# Altium schematic sheet Schematic.SchDoc (continued)

## Library data of the parts
C1 C13 = C0805C152M4RECTU: Ceramic Capacitor, Multilayer, Ceramic, 16V, 20% +Tol, 20% -Tol, X7R, 15% TC, 0.0015uF, Surface Mount, 0805; Manufacturer: KEMET; Capacitor Type: Ceramic; Category: LDO; Component Kind: Standard; ComponentLink1Description: https://datasheet.ciiva.com:443/pdfs/VipMasterIC/IC/KEME/KEME-S-A0008958450/KEME-S-A0008958450-1.pdf?src-supplier=IHS+Markit; ComponentLink1URL: https://datasheet.ciiva.com:443/pdfs/VipMasterIC/IC/KEME/KEME-S-A0008958450/KEME-S-A0008958450-1.pdf?src-supplier=IHS+Markit; Dielectric Material: Ceramic; Library Name: Schlib2.SchLib; Manufacturer Part Number: C0805C152M4RECTU; Pins: 2; Pricing: 1=0.26, 10=0.167, 50=0.167, 100=0.085, 1000=0.072, 10000=0.042 (USD); Reach SVHC Compliant: Yes; RoHS: Yes; RoHS Compliant: Yes; Stock: 7551; Supplier: Mouser; Supplier Part Number: 80-C0805C152M4RECTU
C2 C3 = 16SVPG680M: Aluminum Electrolytic Capacitor, Polarized, Aluminum (solid Polymer), 16V, 20% +Tol, 20% -Tol, 680uF, Surface Mount, 3333; Manufacturer: Panasonic; Category: Polymer Capacitors; Component Kind: Standard; ComponentLink1Description: https://datasheet.octopart.com/16SVPG680M-Panasonic-datasheet-151609408.pdf?src-supplier=Master+Electronics; ComponentLink1URL: https://datasheet.octopart.com/16SVPG680M-Panasonic-datasheet-151609408.pdf?src-supplier=Master+Electronics; Library Name: Schlib2.SchLib; Manufacturer Part Number: 16SVPG680M; Pricing: 1=2.22, 10=1.95, 50=1.88, 100=1.24, 1000=1.24, 10000=1.24 (USD); RoHS: No; RoHS Compliant: Yes; Stock: 1775; Supplier: Mouser; Supplier Part Number: 667-16SVPG680M
C4 C16-C19 = 16SVPG270M: Aluminum Electrolytic Capacitor, Polarized, Aluminum (solid Polymer), 16V, 20% +Tol, 20% -Tol, 270uF, Surface Mount, 2626; Manufacturer: Panasonic; Capacitor Type: Aluminium; ESR (Equivalent Series Resistance): 8mR; Leakage Current: 864uA; Ripple Current: 290mA; Tan Delta: 0.12; Case/Package: 2626; Category: Polymer Capacitors; Component Kind: Standard; ComponentLink1Description: https://datasheet.ciiva.com:443/pdfs/VipMasterIC/IC/PANA/PANA-S-A0009094447/PANA-S-A0009094447-1.pdf?src-supplier=IHS+Markit; ComponentLink1URL: https://datasheet.ciiva.com:443/pdfs/VipMasterIC/IC/PANA/PANA-S-A0009094447/PANA-S-A0009094447-1.pdf?src-supplier=IHS+Markit; ComponentLink2Description: http://industrial.panasonic.com/www-data/pdf/AAB8000/AAB8000CE14.pdf?src-supplier=Avnet; ComponentLink2URL: http://industrial.panasonic.com/www-data/pdf/AAB8000/AAB8000CE14.pdf?src-supplier=Avnet; ComponentLink3Description: http://industrial.panasonic.com/cdbs/www-data/pdf/AAB8000/AAB8000C175.pdf?src-supplier=Farnell; ComponentLink3URL: http://industrial.panasonic.com/cdbs/www-data/pdf/AAB8000/AAB8000C175.pdf?src-supplier=Farnell; ComponentLink4Description: http://www.farnell.com/datasheets/1950075.pdf?src-supplier=Element14; ComponentLink4URL: http://www.farnell.com/datasheets/1950075.pdf?src-supplier=Element14; ComponentLink5Description: https://datasheet.octopart.com/16SVPG270M-Panasonic-datasheet-138704366.pdf?src-supplier=TME; ComponentLink5URL: https://datasheet.octopart.com/16SVPG270M-Panasonic-datasheet-138704366.pdf?src-supplier=TME; ComponentLink6Description: https://datasheet.octopart.com/16SVPG270M-Panasonic-datasheet-151609408.pdf?src-supplier=Master+Electronics; ComponentLink6URL: https://datasheet.octopart.com/16SVPG270M-Panasonic-datasheet-151609408.pdf?src-supplier=Master+Electronics; Diameter: 6.3mm; Dielectric Material: Aluminium; Length: 9.9mm; Library Name: Schlib2.SchLib; Manufacturer Part Number: 16SVPG270M; Max Operating Temperature: 105°C; Min Operating Temperature: -55°C; Mounting Technology: Surface Mount; Package Style: SMTMeter; Packaging: Tape and Reel; Pins: 2; Polarity: Polarized; Pricing: 1=1.62, 10=0.996, 50=0.996, 100=0.896, 1000=0.662, 10000=0.596 (USD); Rated DC Voltage (URdc): 16V; Reach SVHC Compliant: Yes; RoHS: Yes; RoHS Compliant: Yes; Stock: 23509; Supplier: Mouser; Supplier Part Number: 667-16SVPG270M; Terminal Pitch: 2.1mm; Termination: Flat; Tolerance  (fill in as +-): 20%; Tolerance (fill in as +-): 20%; Value: 270uF; Width: 6.6mm
C5 = EKXL401ELL101ML30S: Aluminum Electrolytic Capacitor, Polarized, Aluminum (wet), 400V, 20% +Tol, 20% -Tol, 100uF, Through Hole Mount; Manufacturer: United Chemi-Con; Component Kind: Standard; ComponentLink1Description: https://datasheet.ciiva.com:443/pdfs/VipMasterIC/IC/NIPC/NIPC-S-A0004676570/NIPC-S-A0004676570-1.pdf?src-supplier=IHS+Markit; ComponentLink1URL: https://datasheet.ciiva.com:443/pdfs/VipMasterIC/IC/NIPC/NIPC-S-A0004676570/NIPC-S-A0004676570-1.pdf?src-supplier=IHS+Markit; ComponentLink2Description: http://www.chemi-con.co.jp/cgi-bin/CAT_DB/SEARCH/cat_db_al.cgi?e=e&amp;j=p&amp;pdfname=kxl&src-supplier=Digikey; ComponentLink2URL: http://www.chemi-con.co.jp/cgi-bin/CAT_DB/SEARCH/cat_db_al.cgi?e=e&amp;j=p&amp;pdfname=kxl&src-supplier=Digikey; Library Name: Schlib2.SchLib; Manufacturer Part Number: EKXL401ELL101ML30S; Pricing: 1=2.24, 10=1.72, 50=1.58, 100=1.31, 1000=0.94, 10000=0.711 (USD); RoHS: Yes; Stock: 266; Supplier: Mouser; Supplier Part Number: 661-EKXL401ELL101ML3
C6 = C1206S223KARACTU: Ceramic Capacitor, Multilayer, Ceramic, 250V, 10% +Tol, 10% -Tol, X7R, 15% TC, 0.022uF, Surface Mount, 1206; Manufacturer: KEMET; Multilayer: Yes; Capacitor Type: Ceramic; Case/Package: 1206; Category: Ceramic Capacitors; Component Kind: Standard; ComponentLink1Description: https://datasheet.ciiva.com:443/pdfs/VipMasterIC/IC/KEME/KEME-S-A0008958426/KEME-S-A0008958426-1.pdf?src-supplier=IHS+Markit; ComponentLink1URL: https://datasheet.ciiva.com:443/pdfs/VipMasterIC/IC/KEME/KEME-S-A0008958426/KEME-S-A0008958426-1.pdf?src-supplier=IHS+Markit; ComponentLink2Description: https://datasheet.octopart.com/C1206S223KARACTU-KEMET-datasheet-82521703.pdf?src-supplier=Newark; ComponentLink2URL: https://datasheet.octopart.com/C1206S223KARACTU-KEMET-datasheet-82521703.pdf?src-supplier=Newark; ComponentLink3Description: https://api.kemet.com/component-edge/download/datasheet/C1206S223KARAC7800.pdf?src-supplier=Digikey; ComponentLink3URL: https://api.kemet.com/component-edge/download/datasheet/C1206S223KARAC7800.pdf?src-supplier=Digikey; Dielectric Material: Ceramic; Height: 0.78mm; JESD-609 Code: e3; Length: 3.2mm; Library Name: Schlib2.SchLib; Manufacturer Part Number: C1206S223KARACTU; Max Operating Temperature: 125°C; Min Operating Temperature: -55°C; Package Style: SMTMeter; Packaging: Tape and Reel; Pins: 2; Pricing: 1=0.15, 10=0.06, 50=0.06, 100=0.035, 1000=0.031, 10000=0.024 (USD); Rated DC Voltage (URdc): 250V; Reach SVHC Compliant: Yes; RoHS: Yes; RoHS Compliant: Yes; Stock: 45057; Supplier: Mouser; Supplier Part Number: 80-C1206S223KAR; Temperature Characteristics Code: X7R; Terminal Finish: Nickel; Tolerance  (fill in as +-): 10%; Tolerance (fill in as +-): 10%; Value: 22nF; Width: 1.6mm
C7 = C1206C335K4RACTU: Ceramic Capacitor, Multilayer, Ceramic, 16V, 10% +Tol, 10% -Tol, X7R, 15% TC, 3.3uF, Surface Mount, 1206; Manufacturer: KEMET; Multilayer: Yes; Capacitor Type: Ceramic; Case/Package: 1206; Category: Ceramic Capacitors; Component Kind: Standard; ComponentLink1Description: https://datasheet.ciiva.com:443/pdfs/VipMasterIC/IC/KEME/KEME-S-A0008991654/KEME-S-A0008991654-1.pdf?src-supplier=IHS+Markit; ComponentLink1URL: https://datasheet.ciiva.com:443/pdfs/VipMasterIC/IC/KEME/KEME-S-A0008991654/KEME-S-A0008991654-1.pdf?src-supplier=IHS+Markit; ComponentLink2Description: https://datasheet.ciiva.com/14607/70095383-14607478.pdf?src-supplier=Allied+Electronics; ComponentLink2URL: https://datasheet.ciiva.com/14607/70095383-14607478.pdf?src-supplier=Allied+Electronics; Dielectric Material: Ceramic; Height: 1mm; JESD-609 Code: e3; Length: 3.2mm; Library Name: Schlib2.SchLib; Manufacturer Part Number: C1206C335K4RACTU; Max Operating Temperature: 125°C; Min Operating Temperature: -55°C; Mounting Technology: Surface Mount; Package Shape: Rectangular; Package Style: SMTMeter; Packaging: Tape and Reel; Pins: 2; Pricing: 1=0.31, 10=0.171, 50=0.171, 100=0.11, 1000=0.097, 10000=0.097 (USD); Rated DC Voltage (URdc): 16V; Reach SVHC Compliant: Yes; RoHS: Yes; RoHS Compliant: Yes; Stock: 9267; Supplier: Mouser; Supplier Part Number: 80-C1206C335K4R; Temperature Characteristics Code: X7R; Terminal Finish: Nickel; Termination: Wraparound; Tolerance  (fill in as +-): 10%; Tolerance (fill in as +-): 10%; Value: 3.3uF; Width: 1.6mm
C8 = A768EB107M1ELAE036: Aluminum Electrolytic Capacitor, Polarized, 25V, 20% +Tol, 20% -Tol, 100uF, Surface Mount, 2626; Manufacturer: KEMET; Category: Polymer Capacitors; Component Kind: Standard; Library Name: Schlib2.SchLib; Manufacturer Part Number: A768EB107M1ELAE036; Pricing: 1=0.46, 10=0.292, 50=0.292, 100=0.189, 1000=0.138, 10000=0.138 (USD); RoHS: Yes; Stock: 17392; Supplier: Mouser; Supplier Part Number: 80-A768EB107M1ELAE36
C9 = UUD1V270MCL1GS: Aluminum Electrolytic Capacitor, Polarized, Aluminum (wet), 35V, 20% +Tol, 20% -Tol, 27uF, Surface Mount, 2626; Manufacturer: Nichicon; Capacitor Type: Aluminium; Leakage Current: 9.45uA; Ripple Current: 115mA; Tan Delta: 0.12; Case/Package: 2626; Category: Aluminum Electrolytic Capacitors; Component Kind: Standard; ComponentLink1Description: https://datasheet.ciiva.com:443/pdfs/VipMasterIC/IC/NICH/NICH-S-A0009240538/NICH-S-A0009240538-1.pdf?src-supplier=IHS+Markit; ComponentLink1URL: https://datasheet.ciiva.com:443/pdfs/VipMasterIC/IC/NICH/NICH-S-A0009240538/NICH-S-A0009240538-1.pdf?src-supplier=IHS+Markit; ComponentLink2Description: https://datasheet.ciiva.com/97/e-ud-97009.pdf?src-supplier=Digikey; ComponentLink2URL: https://datasheet.ciiva.com/97/e-ud-97009.pdf?src-supplier=Digikey; ComponentLink3Description: https://datasheet.ciiva.com/97/e-ud-97010.pdf?src-supplier=Digikey; ComponentLink3URL: https://datasheet.ciiva.com/97/e-ud-97010.pdf?src-supplier=Digikey; ComponentLink4Description: https://datasheet.ciiva.com/97/e-ud-97011.pdf?src-supplier=Digikey; ComponentLink4URL: https://datasheet.ciiva.com/97/e-ud-97011.pdf?src-supplier=Digikey; ComponentLink5Description: https://datasheet.ciiva.com/11686/e-ud-11686278.pdf?src-supplier=Avnet; ComponentLink5URL: https://datasheet.ciiva.com/11686/e-ud-11686278.pdf?src-supplier=Avnet; ComponentLink6Description: https://datasheet.ciiva.com/16513/e-ud-16513052.pdf?src-supplier=Future+Electronics; ComponentLink6URL: https://datasheet.ciiva.com/16513/e-ud-16513052.pdf?src-supplier=Future+Electronics; Dielectric Material: Aluminium; Height: 5.8mm; JESD-609 Code: e6; Length: 7mm; Library Name: Schlib2.SchLib; Manufacturer Part Number: UUD1V270MCL1GS; Max Operating Temperature: 105°C; Min Operating Temperature: -55°C; Mounting Technology: Surface Mount; Package Shape: Cylindrical; Package Style: SMTMeter; Packaging: Tape and Reel; Pins: 2; Polarity: Polarized; Pricing: 1=0.47, 10=0.234, 50=0.234, 100=0.162, 1000=0.128, 10000=0.105 (USD); Rated DC Voltage (URdc): 35V; Reach SVHC Compliant: No; RoHS: Yes; RoHS Compliant: Yes; Stock: 2774; Supplier: Mouser; Supplier Part Number: 647-UUD1V270MCL; Terminal Finish: Tin; Termination: Flat; Tolerance  (fill in as +-): 20%; Tolerance (fill in as +-): 20%; Value: 27uF; Width: 6.6mm
C10 = C1210C226M4PAC: Ceramic Capacitor, Multilayer, Ceramic, 16V, 20% +Tol, 20% -Tol, X5R, 15% TC, 22uF, Surface Mount, 1210; Manufacturer: KEMET; Multilayer: Yes; Capacitor Type: Ceramic; Case/Package: 1210; Category: Ceramic Capacitors; Component Kind: Standard; ComponentLink1Description: https://datasheet.ciiva.com:443/pdfs/VipMasterIC/IC/KEME/KEME-S-A0008991665/KEME-S-A0008991665-1.pdf?src-supplier=IHS+Markit; ComponentLink1URL: https://datasheet.ciiva.com:443/pdfs/VipMasterIC/IC/KEME/KEME-S-A0008991665/KEME-S-A0008991665-1.pdf?src-supplier=IHS+Markit; ComponentLink2Description: http://www.mouser.com/ds/2/212/KEM_C1006_X5R_SMD-1103249.pdf?src-supplier=Mouser; ComponentLink2URL: http://www.mouser.com/ds/2/212/KEM_C1006_X5R_SMD-1103249.pdf?src-supplier=Mouser; ComponentLink3Description: http://www.kemet.com/kemet/web/homepage/kechome.nsf/weben/ADB5E2666EC6BA90CA2570A50016092E/$file/KEM_C1005_Y5V_SMD.pdf?src-supplier=Avnet; ComponentLink3URL: http://www.kemet.com/kemet/web/homepage/kechome.nsf/weben/ADB5E2666EC6BA90CA2570A50016092E/$file/KEM_C1005_Y5V_SMD.pdf?src-supplier=Avnet; Dielectric Material: Ceramic; Height: 2.5mm; JESD-609 Code: e3; Length: 3.2mm; Library Name: Schlib2.SchLib; Manufacturer Part Number: C1210C226M4PAC; Max Operating Temperature: 85°C; Min Operating Temperature: -55°C; Mounting Technology: Surface Mount; Package Shape: Rectangular; Package Style: SMTMeter; Packaging: Bulk; Pins: 2; Pricing: 1=0.61, 10=0.554, 50=0.554, 100=0.38, 1000=0.266, 10000=0.221 (USD); Rated DC Voltage (URdc): 16V; Reach SVHC Compliant: Yes; RoHS: Yes; RoHS Compliant: Yes; Stock: 534; Supplier: Mouser; Supplier Part Number: 80-C1210C226M4PAC; Temperature Characteristics Code: X5R; Terminal Finish: Nickel; Termination: Wraparound; Tolerance  (fill in as +-): 20%; Tolerance (fill in as +-): 20%; Value: 22uF; Width: 2.5mm
C11 = C0805C222K4RECAUTO: Ceramic Capacitor, Multilayer, Ceramic, 16V, 10% +Tol, 10% -Tol, X7R, 15% TC, 0.0022uF, Surface Mount, 0805; Manufacturer: KEMET; Capacitor Type: Ceramic; Category: Ceramic Capacitors; Component Kind: Standard; ComponentLink1Description: https://datasheet.ciiva.com:443/pdfs/VipMasterIC/IC/KEME/KEME-S-A0008958450/KEME-S-A0008958450-1.pdf?src-supplier=IHS+Markit; ComponentLink1URL: https://datasheet.ciiva.com:443/pdfs/VipMasterIC/IC/KEME/KEME-S-A0008958450/KEME-S-A0008958450-1.pdf?src-supplier=IHS+Markit; ComponentLink2Description: https://api.kemet.com/component-edge/download/datasheet/C0805C222K4RECAUTO.pdf?src-supplier=Digikey; ComponentLink2URL: https://api.kemet.com/component-edge/download/datasheet/C0805C222K4RECAUTO.pdf?src-supplier=Digikey; Dielectric Material: Ceramic; Library Name: Schlib2.SchLib; Manufacturer Part Number: C0805C222K4RECAUTO; Pins: 2; Pricing: 1=0.24, 10=0.163, 50=0.163, 100=0.093, 1000=0.057, 10000=0.044 (USD); Reach SVHC Compliant: Yes; RoHS: Yes; RoHS Compliant: Yes; Stock: 5770; Supplier: Mouser; Supplier Part Number: 80-C0805C222K4RECAUT
C12 = C0603C104K8RAC: Ceramic Capacitor, Multilayer, Ceramic, 10V, 10% +Tol, 10% -Tol, X7R, 15% TC, 0.1uF, Surface Mount, 0603; Manufacturer: KEMET; Multilayer: Yes; Value: 100nF; Capacitor Type: Ceramic; Case/Package: 0603; Category: Ceramic Capacitors; Component Kind: Standard; ComponentLink1Description: https://datasheet.ciiva.com:443/pdfs/VipMasterIC/IC/KEME/KEME-S-A0008991654/KEME-S-A0008991654-1.pdf?src-supplier=IHS+Markit; ComponentLink1URL: https://datasheet.ciiva.com:443/pdfs/VipMasterIC/IC/KEME/KEME-S-A0008991654/KEME-S-A0008991654-1.pdf?src-supplier=IHS+Markit; ComponentLink2Description: http://www.mouser.com/ds/2/212/KEM_C1002_X7R_SMD-1102033.pdf?src-supplier=Mouser; ComponentLink2URL: http://www.mouser.com/ds/2/212/KEM_C1002_X7R_SMD-1102033.pdf?src-supplier=Mouser; Dielectric Material: Ceramic; Height: 0.8mm; JESD-609 Code: e3; Length: 1.6mm; Library Name: Schlib2.SchLib; Manufacturer Part Number: C0603C104K8RAC; Max Operating Temperature: 125°C; Min Operating Temperature: -55°C; Mounting Technology: Surface Mount; Package Shape: Rectangular; Package Style: SMTMeter; Packaging: Tape and Reel; Pins: 2; Pricing: 1=0.1, 10=0.061, 50=0.061, 100=0.031, 1000=0.022, 10000=0.015 (USD); Rated DC Voltage (URdc): 10V; Reach SVHC Compliant: Yes; RoHS: Yes; RoHS Compliant: Yes; Stock: 209299; Supplier: Mouser; Supplier Part Number: 80-C0603C104K8RAC; Temperature Characteristics Code: X7R; Terminal Finish: Nickel; Termination: Wraparound; Tolerance  (fill in as +-): 10%; Tolerance (fill in as +-): 10%; Width: 0.8mm
C14 = C0805C180JBGACTU: Ceramic Capacitor, Multilayer, Ceramic, 630V, 5% +Tol, 5% -Tol, C0G, 30ppm/Cel TC, 0.000018uF, Surface Mount, 0805; Manufacturer: KEMET; Multilayer: Yes; Capacitor Type: Ceramic; Case/Package: 0805; Category: Ceramic Capacitors; Component Kind: Standard; ComponentLink1Description: https://datasheet.ciiva.com:443/pdfs/VipMasterIC/IC/KEME/KEME-S-A0008491074/KEME-S-A0008491074-1.pdf?src-supplier=IHS+Markit; ComponentLink1URL: https://datasheet.ciiva.com:443/pdfs/VipMasterIC/IC/KEME/KEME-S-A0008491074/KEME-S-A0008491074-1.pdf?src-supplier=IHS+Markit; ComponentLink2Description: https://datasheet.ciiva.com/13376/kem-c1009-c0g-hv-smd-13376539.pdf?src-supplier=Mouser; ComponentLink2URL: https://datasheet.ciiva.com/13376/kem-c1009-c0g-hv-smd-13376539.pdf?src-supplier=Mouser; Dielectric Material: Ceramic; Height: 1.25mm; JESD-609 Code: e3; Length: 2mm; Library Name: Schlib2.SchLib; Manufacturer Part Number: C0805C180JBGACTU; Max Operating Temperature: 125°C; Min Operating Temperature: -55°C; Mounting Technology: Surface Mount; Package Shape: Rectangular; Package Style: SMTMeter; Packaging: Tape and Reel; Pins: 2; Pricing: 1=0.42, 10=0.225, 50=0.225, 100=0.145, 1000=0.124, 10000=0.099 (USD); Rated DC Voltage (URdc): 630V; Reach SVHC Compliant: Yes; RoHS: Yes; RoHS Compliant: Yes; Stock: 2194; Supplier: Mouser; Supplier Part Number: 80-C0805C180JBG; Temperature Characteristics Code: C0G; Terminal Finish: Nickel; Termination: Wraparound; Tolerance  (fill in as +-): 5%; Tolerance (fill in as +-): 5%; Value: 18pF; Width: 1.25mm
C15 = C0805C182M5RACTU: Ceramic Capacitor, Multilayer, Ceramic, 50V, 20% +Tol, 20% -Tol, X7R, 15% TC, 0.0018uF, Surface Mount, 0805; Manufacturer: KEMET; Multilayer: Yes; Capacitor Type: Ceramic; Case/Package: 0805; Category: Ceramic Capacitors; Component Kind: Standard; ComponentLink1Description: https://datasheet.ciiva.com:443/pdfs/VipMasterIC/IC/KEME/KEME-S-A0008991654/KEME-S-A0008991654-1.pdf?src-supplier=IHS+Markit; ComponentLink1URL: https://datasheet.ciiva.com:443/pdfs/VipMasterIC/IC/KEME/KEME-S-A0008991654/KEME-S-A0008991654-1.pdf?src-supplier=IHS+Markit; ComponentLink2Description: http://www.mouser.com/ds/2/212/KEM_C1002_X7R_SMD-1102033.pdf?src-supplier=Mouser; ComponentLink2URL: http://www.mouser.com/ds/2/212/KEM_C1002_X7R_SMD-1102033.pdf?src-supplier=Mouser; ComponentLink3Description: https://search.kemet.com/component-edge/download/datasheet/C0805C182M5RACTU.pdf?src-supplier=Digikey; ComponentLink3URL: https://search.kemet.com/component-edge/download/datasheet/C0805C182M5RACTU.pdf?src-supplier=Digikey; Dielectric Material: Ceramic; Height: 0.78mm; JESD-609 Code: e3; Length: 2mm; Library Name: Schlib2.SchLib; Manufacturer Part Number: C0805C182M5RACTU; Max Operating Temperature: 125°C; Min Operating Temperature: -55°C; Mounting Technology: Surface Mount; Package Shape: Rectangular; Package Style: SMTMeter; Packaging: Tape and Reel; Pins: 2; Pricing: 1=0.16, 10=0.113, 50=0.113, 100=0.094, 1000=0.062, 10000=0.044 (USD); Rated DC Voltage (URdc): 50V; Reach SVHC Compliant: Yes; RoHS: Yes; RoHS Compliant: Yes; Stock: 3640; Supplier: Mouser; Supplier Part Number: 80-C0805C182M5R; Temperature Characteristics Code: X7R; Terminal Finish: Nickel; Termination: Wraparound; Tolerance  (fill in as +-): 20%; Tolerance (fill in as +-): 20%; Value: 1.8nF; Width: 1.25mm
C20 = C0805C101J5GAC3123: Multilayer Ceramic Capacitors MLCC - SMD/SMT 50volts 100pF C0G 5%; Manufacturer: KEMET; Category: Ceramic Capacitors; Component Kind: Standard; ComponentLink1Description: https://datasheet.ciiva.com/5439/kem-c1003-c0g-smd-356956-5439045.pdf?src-supplier=Mouser; ComponentLink1URL: https://datasheet.ciiva.com/5439/kem-c1003-c0g-smd-356956-5439045.pdf?src-supplier=Mouser; Library Name: Schlib2.SchLib; Manufacturer Part Number: C0805C101J5GAC3123; Pricing: 1=0.13, 10=0.089, 50=0.089, 100=0.042, 1000=0.025, 10000=0.017 (USD); RoHS: Yes; Stock: 6802; Supplier: Mouser; Supplier Part Number: 80-C0805C101J5G3123
CONN1-CONN3 = CONNECTOR: Strip Terminal Block, 24A, 2.5mm2, 1 Row(s), 1 Deck(s); Manufacturer: Weidmuller; Additional Feature: STANDARD: UL 94V-0; Fastening Method: SOLDER; Number of Decks: 1; Number of Ways: 2; Safety Approval: UL,CSA; Voltage Rating: 600V; Wire/Cable Gauge: 14AWG; Category: Terminal Blocks; Component Kind: Standard; ComponentLink1Description: https://datasheet.ciiva.com:443/pdfs/VipMasterIC/IC/WEID/WEIDS07857/WEIDS07857-1.pdf?src-supplier=IHS+Markit; ComponentLink1URL: https://datasheet.ciiva.com:443/pdfs/VipMasterIC/IC/WEID/WEIDS07857/WEIDS07857-1.pdf?src-supplier=IHS+Markit; ComponentLink2Description: https://datasheet.ciiva.com/823/1760510000-823577.pdf?src-supplier=Digikey; ComponentLink2URL: https://datasheet.ciiva.com/823/1760510000-823577.pdf?src-supplier=Digikey; ComponentLink3Description: https://datasheet.ciiva.com/7143/1712606-7143944.pdf?src-supplier=Newark; ComponentLink3URL: https://datasheet.ciiva.com/7143/1712606-7143944.pdf?src-supplier=Newark; ComponentLink4Description: https://datasheet.ciiva.com/16071/1760510000-pm-5-08-02-90-3-5sn-bk-bx-en-pdf-154-16071541.pdf?src-supplier=Onlinecomponents.com; ComponentLink4URL: https://datasheet.ciiva.com/16071/1760510000-pm-5-08-02-90-3-5sn-bk-bx-en-pdf-154-16071541.pdf?src-supplier=Onlinecomponents.com; Current Rating: 24A; Library Name: Schlib2.SchLib; Manufacturer Part Number: 1760510000; Mounting Technology: Board; Number of Rows: 1; Pricing: 1=0.89, 10=0.554, 25=0.474, 50=0.4262, 100=0.4173, 250=0.35512, 500=0.33736, 1000=0.30185, 5000=0.23615 (USD); Reach SVHC Compliant: Yes; RoHS: Yes; RoHS Compliant: Yes; Stock: 49588; Supplier: Digikey; Supplier Part Number: 281-1882-ND; Terminal and Terminal Block Type: STRIP TERMINAL BLOCK; Wire Cross Section: 2.5mm2
D1 D6 = 150060VS75000: Single Color LED, Bright Green, Water Clear, 1.1mm; Manufacturer: Wurth Electronics; Channels: 1; Forward Current: 30mA; Luminous Intensity-Nom: 40mcd; Overall Height: 0.7mm; Shape: Rectangular; Viewing Angle: 140°; Category: LEDs; Color: Green; Component Kind: Standard; ComponentLink1Description: https://datasheet.ciiva.com:443/pdfs/VipMasterIC/IC/MIDC/MIDC-S-A0007768636/MIDC-S-A0007768636-1.pdf?src-supplier=IHS+Markit; ComponentLink1URL: https://datasheet.ciiva.com:443/pdfs/VipMasterIC/IC/MIDC/MIDC-S-A0007768636/MIDC-S-A0007768636-1.pdf?src-supplier=IHS+Markit; ComponentLink2Description: https://datasheet.ciiva.com/29562/150060vs75000-29562919.pdf?src-supplier=Digikey; ComponentLink2URL: https://datasheet.ciiva.com/29562/150060vs75000-29562919.pdf?src-supplier=Digikey; Lens Style: WATER CLEAR; Library Name: Schlib2.SchLib; Manufacturer Part Number: 150060VS75000; Max Operating Temperature: 85°C; Min Operating Temperature: -40°C; Mounting Technology: Surface Mount; Optoelectronic Device Type: SINGLE COLOR LED; Packaging: Tape and Reel; Pins: 2; Pricing: 1=0.15, 50=0.142, 100=0.122, 500=0.105, 1000=0.10125 (USD); Reach SVHC Compliant: Yes; RoHS: Yes; RoHS Compliant: Yes; Size: 1.1mm; Stock: 90757; Supplier: Digikey; Supplier Part Number: 732-4980-1-ND; Terminal Pitch: 1.6mm
D2 D5 = V10P22CHM3/H: Schottky Diodes & Rectifiers 10A 200V SMPC TRENCH SKY REC; Manufacturer: Vishay Intertechnology; Component Kind: Standard; Library Name: Schlib2.SchLib; Manufacturer Part Number: V10P22CHM3/H; Pricing: 1=1, 10=0.819, 50=0.819, 100=0.637, 1000=0.44, 10000=0.413 (USD); RoHS: No; Stock: 1323; Supplier: Mouser; Supplier Part Number: 78-V10P22CHM3/H
D3 = US1M-M3/5AT: Rectifier Diode, 1 Phase, 1 Element, 1A, 1000V V(RRM), Silicon, DO-214AC; Manufacturer: Vishay Semiconductors; Additional Feature: FREE WHEELING DIODE; Number of Elements: 1; Package Body Material: Plastic; Rep Pk Reverse Voltage-Max: 1kV; Reverse Recovery Time-Max: 0.075us; Category: Rectifier Diodes; Component Kind: Standard; ComponentLink1Description: https://datasheet.ciiva.com:443/pdfs/VipMasterIC/IC/VISH/VISH-S-A0003405547/VISH-S-A0003405547-1.pdf?src-supplier=IHS+Markit; ComponentLink1URL: https://datasheet.ciiva.com:443/pdfs/VipMasterIC/IC/VISH/VISH-S-A0003405547/VISH-S-A0003405547-1.pdf?src-supplier=IHS+Markit; ComponentLink2Description: https://datasheet.ciiva.com/29886/us1-29886014.pdf?src-supplier=Avnet; ComponentLink2URL: https://datasheet.ciiva.com/29886/us1-29886014.pdf?src-supplier=Avnet; Diode Element Material: SILICON; Diode Type: RECTIFIER; JEDEC-95 Code: DO-214AC; JESD-30 Code: R-PDSO-C2; JESD-609 Code: e3; Library Name: Schlib2.SchLib; Manufacturer Part Number: US1M-M3/5AT; Max Operating Temperature: 150°C; Max Output current: 1A; Min Operating Temperature: -55°C; Package Shape: Rectangular; Package Style: SMALL OUTLINEMeter; Pins: 2; Pricing: 1=0.31, 10=0.209, 50=0.209, 100=0.109, 1000=0.089, 10000=0.065 (USD); Reach SVHC Compliant: No; RoHS: Yes; RoHS Compliant: Yes; Stock: 35964; Supplier: Mouser; Supplier Part Number: 78-US1M-M35AT; Surface Mount: Yes; Terminal Finish: Tin; Terminal Form: C BEND; Terminal Position: DUAL
D4 = VS-MBRS1100-M3/5BT: Rectifier Diode, Schottky, 1 Phase, 1 Element, 1A, 100V V(RRM), Silicon; Manufacturer: Vishay Semiconductors; Additional Feature: FREE WHEELING DIODE; Number of Elements: 1; Package Body Material: Plastic; Category: Rectifier Diodes; Component Kind: Standard; ComponentLink1Description: https://datasheet.ciiva.com:443/pdfs/VipMasterIC/IC/VISH/VISH-S-A0009498371/VISH-S-A0009498371-1.pdf?src-supplier=IHS+Markit; ComponentLink1URL: https://datasheet.ciiva.com:443/pdfs/VipMasterIC/IC/VISH/VISH-S-A0009498371/VISH-S-A0009498371-1.pdf?src-supplier=IHS+Markit; ComponentLink2Description: http://www.farnell.com/datasheets/2119788.pdf?src-supplier=Farnell; ComponentLink2URL: http://www.farnell.com/datasheets/2119788.pdf?src-supplier=Farnell; ComponentLink3Description: http://www.mouser.com/ds/2/427/vsmbrs190m3-552910.pdf?src-supplier=Mouser; ComponentLink3URL: http://www.mouser.com/ds/2/427/vsmbrs190m3-552910.pdf?src-supplier=Mouser; ComponentLink4Description: http://www.vishay.com/docs/95744/vsmbrs190m3.pdf?src-supplier=Digikey; ComponentLink4URL: http://www.vishay.com/docs/95744/vsmbrs190m3.pdf?src-supplier=Digikey; ComponentLink5Description: https://www.vishay.com/docs/95744/vsmbrs190m3.pdf?src-supplier=Digikey; ComponentLink5URL: https://www.vishay.com/docs/95744/vsmbrs190m3.pdf?src-supplier=Digikey; ComponentLink6Description: https://datasheet.octopart.com/VS-MBRS1100-M3-5BT-Vishay-datasheet-66581896.pdf?src-supplier=Newark; ComponentLink6URL: https://datasheet.octopart.com/VS-MBRS1100-M3-5BT-Vishay-datasheet-66581896.pdf?src-supplier=Newark; ComponentLink7Description: http://www.farnell.com/datasheets/2119788.pdf?src-supplier=Element14; ComponentLink7URL: http://www.farnell.com/datasheets/2119788.pdf?src-supplier=Element14; ComponentLink8Description: https://datasheet.octopart.com/VS-MBRS1100-M3-5BT-Vishay-datasheet-48227625.pdf?src-supplier=Future+Electronics; ComponentLink8URL: https://datasheet.octopart.com/VS-MBRS1100-M3-5BT-Vishay-datasheet-48227625.pdf?src-supplier=Future+Electronics; Diode Element Material: SILICON; Diode Type: RECTIFIER; JESD-30 Code: R-PDSO-C2; JESD-609 Code: e3; Library Name: Schlib2.SchLib; Manufacturer Part Number: VS-MBRS1100-M3/5BT; Max Operating Temperature: 175°C; Min Operating Temperature: -55°C; Moisture Sensitivity Level: 1; Package Shape: Rectangular; Package Style: SMALL OUTLINEMeter; Peak Reflow Temperature (Cel): 260°C; Pins: 2; Pricing: 1=0.35, 10=0.237, 50=0.237, 100=0.122, 1000=0.113, 10000=0.075 (USD); Reach SVHC Compliant: No; Rep Pk Reverse Voltage-Max: 100V; RoHS: Yes; RoHS Compliant: Yes; Stock: 190719; Supplier: Mouser; Supplier Part Number: 78-VS-MBRS1100-M35BT; Surface Mount: Yes; Technology: SCHOTTKY; Terminal Finish: Tin; Terminal Form: C BEND; Terminal Position: DUAL
L1 = BDQQ00201212R11MPA: Power Inductor, 0.11UH, Shielded, 10A Rohs Compliant: Yes; Manufacturer: Pulse; Component Kind: Standard; Library Name: Schlib2.SchLib; Manufacturer Part Number: BDQQ00201212R11MPA; Pricing: 1=0.21, 10=0.177, 50=0.177, 100=0.126, 1000=0.086, 10000=0.073 (USD); RoHS: No; Stock: 4600; Supplier: Mouser; Supplier Part Number: 673-BDQQ201212R11MPA
L2 = AIAC-2712C-17N5J-T: General Purpose Inductor, 0.0175uH, 5%, 1 Element, Air-Core, SMD, 2310; Manufacturer: Abracon; Channels: 1; Core Material: Air; DC Resistance (DCR): 4.5mR; Inductor Application: HIGH CURRENT INDUCTOR; Max Current Rating: 4A; Quality Factor-Min (at L-nom): 100; Self Resonant Frequency: 2.2GHz; Shape/Size Description: RECTANGULAR PACKAGE; Shielding: Unshielded; Test Frequency: 150MHz; Category: Fixed Inductors; Component Kind: Standard; ComponentLink1Description: https://datasheet.ciiva.com:443/pdfs/VipMasterIC/IC/ABRC/ABRC-S-A0008586417/ABRC-S-A0008586417-1.pdf?src-supplier=IHS+Markit; ComponentLink1URL: https://datasheet.ciiva.com:443/pdfs/VipMasterIC/IC/ABRC/ABRC-S-A0008586417/ABRC-S-A0008586417-1.pdf?src-supplier=IHS+Markit; ComponentLink2Description: https://datasheet.ciiva.com/13313/aiac-2712c-218660-13313076.pdf?src-supplier=Mouser; ComponentLink2URL: https://datasheet.ciiva.com/13313/aiac-2712c-218660-13313076.pdf?src-supplier=Mouser; ComponentLink3Description: https://datasheet.ciiva.com/6691/aiac-2712c-6691014.pdf?src-supplier=Digikey; ComponentLink3URL: https://datasheet.ciiva.com/6691/aiac-2712c-6691014.pdf?src-supplier=Digikey; ComponentLink4Description: https://datasheet.ciiva.com/6691/aiac-2712c-6691016.pdf?src-supplier=Digikey; ComponentLink4URL: https://datasheet.ciiva.com/6691/aiac-2712c-6691016.pdf?src-supplier=Digikey; Inductance-Nom (L): 0.0175uH; Inductor Type: GENERAL PURPOSE INDUCTOR; Library Name: Schlib2.SchLib; Manufacturer Part Number: AIAC-2712C-17N5J-T; Max Operating Temperature: 125°C; Min Operating Temperature: -40°C; Pins: 2; Pricing: 1=0.26, 10=0.25, 50=0.25, 100=0.248, 1000=0.247, 10000=0.222 (USD); Reach SVHC Compliant: Yes; RoHS: Yes; RoHS Compliant: Yes; Stock: 3506; Supplier: Mouser; Supplier Part Number: 815-AIAC2712C17N5JT; Surface Mount: Yes; Terminal Placement: Dual Ended; Termination: Flat; Tolerance: 5%
Q1 = IPD60R180CM8XTMA1: Mosfet, N-ch, 600V, 18A, TO-252;; Manufacturer: Infineon; Category: MOSFETs; Component Kind: Standard; Library Name: Schlib2.SchLib; Manufacturer Part Number: IPD60R180CM8XTMA1; Pricing: 1=2.48, 10=1.6, 100=1.0956, 500=0.87982, 1000=0.81047 (USD); RoHS: No; Stock: 620; Supplier: Digikey; Supplier Part Number: 448-IPD60R180CM8XTMA1CT-ND
R1 = RT0805DRD07120RL: Fixed Resistor, Thin Film, 0.125W, 120ohm, 150V, 0.5% +/-Tol, 25ppm/Cel, Surface Mount, 0805; Manufacturer: Yageo; Additional Feature: HIGH PRECISION; Package Height: 0.5mm; Package Length: 2mm; Package Width: 1.25mm; Power: 125mW; Rated Temperature: 70°C; Temperature Coefficient: 25ppm/°C; Case/Package: 0805; Category: Chip SMD Resistors; Component Kind: Standard; ComponentLink1Description: https://datasheet.ciiva.com:443/pdfs/VipMasterIC/IC/YAGO/YAGO-S-A0008510989/YAGO-S-A0008510989-1.pdf?src-supplier=IHS+Markit; ComponentLink1URL: https://datasheet.ciiva.com:443/pdfs/VipMasterIC/IC/YAGO/YAGO-S-A0008510989/YAGO-S-A0008510989-1.pdf?src-supplier=IHS+Markit; ComponentLink2Description: http://www.yageo.com/documents/recent/PYu-RT_1-to-0.01_RoHS_L_9.pdf?src-supplier=Digikey; ComponentLink2URL: http://www.yageo.com/documents/recent/PYu-RT_1-to-0.01_RoHS_L_9.pdf?src-supplier=Digikey; Construction: Chip; Library Name: Schlib2.SchLib; Manufacturer Part Number: RT0805DRD07120RL; Max Operating Temperature: 155°C; Min Operating Temperature: -55°C; Mounting Technology: Surface Mount; Package Style: SMTMeter; Packaging: Tape and Reel; Pins: 2; Pricing: 1=0.1, 10=0.076, 50=0.0606, 100=0.0545, 500=0.0418, 1000=0.03701 (USD); Reach SVHC Compliant: Yes; Resistor Type: FIXED RESISTOR; RoHS: Yes; RoHS Compliant: Yes; Stock: 4920; Supplier: Digikey; Supplier Part Number: 13-RT0805DRD07120RLCT-ND; Technology: THIN FILM; Termination: Wraparound; Tolerance: 0.5%; Value: 120R; Working Voltage: 150V
R2 R19 = RNCL1206FTR500: Thin Film Resistors - SMD 0.5Ohms 1206 1W 1% HiPow Anti-Surge AEC-Q200; Manufacturer: Stackpole Electronics; Component Kind: Standard; Library Name: Schlib2.SchLib; Manufacturer Part Number: RNCL1206FTR500; Pricing: 1=0.36, 10=0.255, 50=0.197, 100=0.175, 1000=0.111, 10000=0.093 (USD); RoHS: No; Stock: 7659; Supplier: Mouser; Supplier Part Number: 708-RNCL1206FTR500
R3 R14 = RT0603BRE0750RL: Fixed Resistor, Thin Film, 0.1W, 50ohm, 75V, 0.1% +/-Tol, 50ppm/Cel, Surface Mount, 0603; Manufacturer: Yageo; Additional Feature: LASER TRIMMABLE; Power: 100mW; Rated Temperature: 70°C; Temperature Coefficient (ppm/Cel): 50; Case/Package: 0603; Category: Chip SMD Resistors; Component Kind: Standard; ComponentLink1Description: https://datasheet.ciiva.com:443/pdfs/VipMasterIC/IC/YAGO/YAGO-S-A0003507815/YAGO-S-A0003507815-1.pdf?src-supplier=IHS+Markit; ComponentLink1URL: https://datasheet.ciiva.com:443/pdfs/VipMasterIC/IC/YAGO/YAGO-S-A0003507815/YAGO-S-A0003507815-1.pdf?src-supplier=IHS+Markit; ComponentLink2Description: http://www.yageo.com/documents/recent/PYu-RT_1-to-0.01_RoHS_L_9.pdf?src-supplier=Digikey; ComponentLink2URL: http://www.yageo.com/documents/recent/PYu-RT_1-to-0.01_RoHS_L_9.pdf?src-supplier=Digikey; ComponentLink3Description: https://datasheet.octopart.com/RT0603BRE0750RL-Yageo-datasheet-166250672.pdf?src-supplier=Future+Electronics; ComponentLink3URL: https://datasheet.octopart.com/RT0603BRE0750RL-Yageo-datasheet-166250672.pdf?src-supplier=Future+Electronics; Library Name: Schlib2.SchLib; Manufacturer Part Number: RT0603BRE0750RL; Max Operating Temperature: 155°C; Min Operating Temperature: -55°C; Mounting Technology: Surface Mount; Package Shape: Rectangular; Packaging: Tape and Reel; Pins: 2; Pricing: 1=0.18, 10=0.131, 50=0.103, 100=0.092, 1000=0.065, 10000=0.044 (USD); Reach SVHC Compliant: Yes; Resistor Type: FIXED RESISTOR; RoHS: Yes; RoHS Compliant: Yes; Stock: 12310; Supplier: Mouser; Supplier Part Number: 603-RT0603BRE0750RL; Technology: THIN FILM; Termination: Wraparound; Tolerance: 0.1%; Value: 50R; Working Voltage: 75V
R4 R10 = RT1206BRD0750KL: Fixed Resistor, Thin Film, 0.25W, 50000ohm, 200V, 0.1% +/-Tol, 25ppm/Cel, Surface Mount, 1206; Manufacturer: Yageo; Additional Feature: LASER TRIMMABLE; Power: 250mW; Rated Temperature: 70°C; Temperature Coefficient (ppm/Cel): 25; Value: 50kR; Case/Package: 1206; Category: Chip SMD Resistors; Component Kind: Standard; ComponentLink1Description: https://datasheet.ciiva.com:443/pdfs/VipMasterIC/IC/YAGO/YAGO-S-A0003507815/YAGO-S-A0003507815-1.pdf?src-supplier=IHS+Markit; ComponentLink1URL: https://datasheet.ciiva.com:443/pdfs/VipMasterIC/IC/YAGO/YAGO-S-A0003507815/YAGO-S-A0003507815-1.pdf?src-supplier=IHS+Markit; ComponentLink2Description: https://datasheet.octopart.com/RT1206BRD0750KL-Yageo-datasheet-166250672.pdf?src-supplier=Future+Electronics; ComponentLink2URL: https://datasheet.octopart.com/RT1206BRD0750KL-Yageo-datasheet-166250672.pdf?src-supplier=Future+Electronics; ComponentLink3Description: http://www.yageo.com/documents/recent/PYu-RT_1-to-0.01_RoHS_L_8.pdf?src-supplier=Digikey; ComponentLink3URL: http://www.yageo.com/documents/recent/PYu-RT_1-to-0.01_RoHS_L_8.pdf?src-supplier=Digikey; Library Name: Schlib2.SchLib; Manufacturer Part Number: RT1206BRD0750KL; Max Operating Temperature: 155°C; Min Operating Temperature: -55°C; Mounting Technology: Surface Mount; Package Shape: Rectangular; Packaging: Tape and Reel; Pins: 2; Pricing: 1=0.34, 10=0.244, 50=0.189, 100=0.166, 1000=0.112, 10000=0.089 (USD); Reach SVHC Compliant: Yes; Resistor Type: FIXED RESISTOR; RoHS: Yes; RoHS Compliant: Yes; Stock: 3546; Supplier: Mouser; Supplier Part Number: 603-RT1206BRD0750KL; Technology: THIN FILM; Termination: Wraparound; Tolerance: 0.1%; Working Voltage: 200V
R5 = RT0805BRD076K73L: Fixed Resistor, Thin Film, 0.125W, 6730ohm, 150V, 0.1% +/-Tol, 25ppm/Cel, Surface Mount, 0805; Manufacturer: Yageo; Additional Feature: LASER TRIMMABLE; Package Height: 0.5mm; Package Length: 2mm; Package Width: 1.25mm; Power: 125mW; Rated Temperature: 70°C; Temperature Coefficient (ppm/Cel): 25; Value: 6.73kR; Case/Package: 0805; Category: Chip SMD Resistors; Component Kind: Standard; ComponentLink1Description: https://datasheet.ciiva.com:443/pdfs/VipMasterIC/IC/YAGO/YAGO-S-A0008510989/YAGO-S-A0008510989-1.pdf?src-supplier=IHS+Markit; ComponentLink1URL: https://datasheet.ciiva.com:443/pdfs/VipMasterIC/IC/YAGO/YAGO-S-A0008510989/YAGO-S-A0008510989-1.pdf?src-supplier=IHS+Markit; ComponentLink2Description: http://www.yageo.com/documents/recent/PYu-RT_1-to-0.01_RoHS_L_8.pdf?src-supplier=Digikey; ComponentLink2URL: http://www.yageo.com/documents/recent/PYu-RT_1-to-0.01_RoHS_L_8.pdf?src-supplier=Digikey; Construction: Chip; JESD-609 Code: e3; Library Name: Schlib2.SchLib; Manufacturer Part Number: RT0805BRD076K73L; Max Operating Temperature: 155°C; Min Operating Temperature: -55°C; Mounting Technology: Surface Mount; Package Shape: Rectangular; Packaging: Tape and Reel; Pins: 2; Pricing: 1=0.17, 10=0.123, 50=0.097, 100=0.087, 1000=0.06, 10000=0.038 (USD); Reach SVHC Compliant: Yes; Resistor Type: FIXED RESISTOR; RoHS: Yes; RoHS Compliant: Yes; Stock: 9017; Supplier: Mouser; Supplier Part Number: 603-RT0805BRD076K73L; Technology: THIN FILM; Terminal Finish: Nickel; Termination: Wraparound; Tolerance: 0.1%; Working Voltage: 150V
R6 = ERA-6AHD21R0V: Fixed Resistor, Thin Film, 0.125W, 21ohm, 100V, 0.5% +/-Tol, 50ppm/Cel, Surface Mount, 0805; Manufacturer: Panasonic; Package Height: 0.5mm; Package Length: 2mm; Package Width: 1.25mm; Power: 125mW; Rated Temperature: 85°C; Reference Standard: AEC-Q200; Temperature Coefficient (ppm/Cel): 50; Case/Package: 0805; Category: Chip SMD Resistors; Component Kind: Standard; ComponentLink1Description: https://datasheet.ciiva.com:443/pdfs/VipMasterIC/IC/PANA/PANA-S-A0009459258/PANA-S-A0009459258-1.pdf?src-supplier=IHS+Markit; ComponentLink1URL: https://datasheet.ciiva.com:443/pdfs/VipMasterIC/IC/PANA/PANA-S-A0009459258/PANA-S-A0009459258-1.pdf?src-supplier=IHS+Markit; ComponentLink2Description: https://datasheet.ciiva.com/24030/aoa0000ce26-197354-24030725.pdf?src-supplier=Mouser; ComponentLink2URL: https://datasheet.ciiva.com/24030/aoa0000ce26-197354-24030725.pdf?src-supplier=Mouser; ComponentLink3Description: https://datasheet.ciiva.com/9191/aoa0000ce26-9191944.pdf?src-supplier=Avnet; ComponentLink3URL: https://datasheet.ciiva.com/9191/aoa0000ce26-9191944.pdf?src-supplier=Avnet; Construction: Rectangular; JESD-609 Code: e3; Library Name: Schlib2.SchLib; Manufacturer Part Number: ERA-6AHD21R0V; Max Operating Temperature: 155°C; Min Operating Temperature: -55°C; Mounting Technology: Surface Mount; Packaging: Tape and Reel; Pins: 2; Pricing: 1=0.19, 10=0.138, 50=0.138, 100=0.126, 1000=0.126, 10000=0.051 (USD); Reach SVHC Compliant: Yes; Resistor Type: FIXED RESISTOR; RoHS: Yes; RoHS Compliant: Yes; Stock: 19880; Supplier: Mouser; Supplier Part Number: 667-ERA-6AHD21R0V; Technology: THIN FILM; Terminal Finish: Nickel; Termination: Wraparound; Tolerance: 0.5%; Value: 21R; Working Voltage: 100V
R7 = AA0805FR-0739RL: Fixed Resistor, Metal Glaze/thick Film, 0.125W, 39ohm, 150V, 1% +/-Tol, 150ppm/Cel, Surface Mount, 0805; Manufacturer: Yageo; Additional Feature: LASER TRIMMABLE; Package Height: 0.5mm; Package Length: 2mm; Package Width: 1.25mm; Power: 125mW; Rated Temperature: 70°C; Reference Standard: AEC-Q200; Temperature Coefficient (ppm/Cel): 150; Case/Package: 0805; Category: Chip SMD Resistors; Component Kind: Standard; ComponentLink1Description: https://datasheet.ciiva.com:443/pdfs/VipMasterIC/IC/YAGO/YAGO-S-A0003674169/YAGO-S-A0003674169-1.pdf?src-supplier=IHS+Markit; ComponentLink1URL: https://datasheet.ciiva.com:443/pdfs/VipMasterIC/IC/YAGO/YAGO-S-A0003674169/YAGO-S-A0003674169-1.pdf?src-supplier=IHS+Markit; ComponentLink2Description: http://www.yageo.com/NewPortal/yageodocoutput?fileName=/pdf/R-Chip/PYu-AA_51_RoHS_L_3.pdf&src-supplier=Digikey; ComponentLink2URL: http://www.yageo.com/NewPortal/yageodocoutput?fileName=/pdf/R-Chip/PYu-AA_51_RoHS_L_3.pdf&src-supplier=Digikey; Construction: Chip; JESD-609 Code: e3; Library Name: Schlib2.SchLib; Manufacturer Part Number: AA0805FR-0739RL; Max Operating Temperature: 155°C; Min Operating Temperature: -55°C; Mounting Technology: Surface Mount; Package Shape: Rectangular; Packaging: Tape and Reel; Pins: 2; Pricing: 1=0.1, 10=0.027, 50=0.027, 100=0.024, 1000=0.015, 10000=0.007 (USD); Reach SVHC Compliant: Yes; Resistor Type: FIXED RESISTOR; RoHS: Yes; RoHS Compliant: Yes; Stock: 4035; Supplier: Mouser; Supplier Part Number: 603-AA0805FR-0739RL; Technology: METAL GLAZE/THICK FILM; Terminal Finish: Nickel; Termination: Wraparound; Tolerance: 1%; Value: 39R; Working Voltage: 150V
R8 = RT0805FRE07715RL: Fixed Resistor, Thin Film, 0.125W, 715ohm, 150V, 1% +/-Tol, 50ppm/Cel, Surface Mount, 0805; Manufacturer: Yageo; Additional Feature: HIGH PRECISION; Package Height: 0.5mm; Package Length: 2mm; Package Width: 1.25mm; Power: 125mW; Rated Temperature: 70°C; Temperature Coefficient (ppm/Cel): 50; Case/Package: 0805; Category: Chip SMD Resistors; Component Kind: Standard; ComponentLink1Description: https://datasheet.ciiva.com:443/pdfs/VipMasterIC/IC/YAGO/YAGO-S-A0008510989/YAGO-S-A0008510989-1.pdf?src-supplier=IHS+Markit; ComponentLink1URL: https://datasheet.ciiva.com:443/pdfs/VipMasterIC/IC/YAGO/YAGO-S-A0008510989/YAGO-S-A0008510989-1.pdf?src-supplier=IHS+Markit; ComponentLink2Description: https://datasheet.ciiva.com/2561/pyu-rt-1-to-0-05-rohs-l-5-2561373.pdf?src-supplier=Digikey; ComponentLink2URL: https://datasheet.ciiva.com/2561/pyu-rt-1-to-0-05-rohs-l-5-2561373.pdf?src-supplier=Digikey; Construction: Chip; Library Name: Schlib2.SchLib; Manufacturer Part Number: RT0805FRE07715RL; Max Operating Temperature: 155°C; Min Operating Temperature: -55°C; Mounting Technology: Surface Mount; Packaging: Tape and Reel; Pins: 2; Pricing: 1=0.1, 10=0.026, 50=0.026, 100=0.021, 1000=0.019, 10000=0.013 (USD); Reach SVHC Compliant: Yes; Resistor Type: FIXED RESISTOR; RoHS: Yes; RoHS Compliant: Yes; Stock: 18735; Supplier: Mouser; Supplier Part Number: 603-RT0805FRE07715RL; Technology: THIN FILM; Termination: Wraparound; Tolerance: 1%; Value: 715R; Working Voltage: 150V
R9 R13 R18 = RT0805BRD071KL: Fixed Resistor, Thin Film, 0.125W, 1000ohm, 150V, 0.1% +/-Tol, 25ppm/Cel, Surface Mount, 0805; Manufacturer: Yageo; Additional Feature: HIGH PRECISION; Package Height: 0.5mm; Package Length: 2mm; Package Width: 1.25mm; Power: 125mW; Rated Temperature: 70°C; Temperature Coefficient: 25ppm/°C; Value: 1kR; Case/Package: 0805; Category: Chip SMD Resistors; Component Kind: Standard; ComponentLink1Description: https://datasheet.ciiva.com:443/pdfs/VipMasterIC/IC/YAGO/YAGO-S-A0008510989/YAGO-S-A0008510989-1.pdf?src-supplier=IHS+Markit; ComponentLink1URL: https://datasheet.ciiva.com:443/pdfs/VipMasterIC/IC/YAGO/YAGO-S-A0008510989/YAGO-S-A0008510989-1.pdf?src-supplier=IHS+Markit; ComponentLink2Description: https://datasheet.ciiva.com/46635/pyu-rt-1-to-0-05-rohs-l-5-46635037.pdf?src-supplier=Digikey; ComponentLink2URL: https://datasheet.ciiva.com/46635/pyu-rt-1-to-0-05-rohs-l-5-46635037.pdf?src-supplier=Digikey; ComponentLink3Description: https://datasheet.ciiva.com/12836/pyu-rt-1-to-0-05-rohs-l-4-12836655.pdf?src-supplier=Mouser; ComponentLink3URL: https://datasheet.ciiva.com/12836/pyu-rt-1-to-0-05-rohs-l-4-12836655.pdf?src-supplier=Mouser; ComponentLink4Description: https://datasheet.ciiva.com/8896/pyu-rt-1-to-0-05-rohs-l-4-8896157.pdf?src-supplier=Avnet; ComponentLink4URL: https://datasheet.ciiva.com/8896/pyu-rt-1-to-0-05-rohs-l-4-8896157.pdf?src-supplier=Avnet; ComponentLink5Description: https://datasheet.ciiva.com/2584/pyu-rt-1-to-0-05-rohs-l-4-2584828.pdf?src-supplier=Digikey; ComponentLink5URL: https://datasheet.ciiva.com/2584/pyu-rt-1-to-0-05-rohs-l-4-2584828.pdf?src-supplier=Digikey; Construction: Chip; Library Name: Schlib2.SchLib; Manufacturer Part Number: RT0805BRD071KL; Max Operating Temperature: 155°C; Min Operating Temperature: -55°C; Mounting Technology: Surface Mount; Package Style: SMTMeter; Packaging: Tape and Reel; Pins: 2; Pricing: 1=0.16, 10=0.12, 50=0.0946, 100=0.0846, 500=0.06418, 1000=0.05663 (USD); Reach SVHC Compliant: Yes; Resistor Type: FIXED RESISTOR; RoHS: Yes; RoHS Compliant: Yes; Stock: 107171; Supplier: Digikey; Supplier Part Number: YAG1820CT-ND; Technology: THIN FILM; Termination: Wraparound; Tolerance: 0.1%; Working Voltage: 150V
R11 R12 = RC0805DR-072K2L: Fixed Resistor, Metal Glaze/thick Film, 0.125W, 2200ohm, 150V, 0.5% +/-Tol, 100ppm/Cel, Surface Mount, 0805; Manufacturer: Yageo; Additional Feature: LASER TRIMMABLE; Package Height: 0.5mm; Package Length: 2mm; Package Width: 1.25mm; Power: 125mW; Rated Temperature: 70°C; Temperature Coefficient: 100ppm/°C; Value: 2.2kR; Case/Package: 0805; Category: Chip SMD Resistors; Component Kind: Standard; ComponentLink1Description: https://datasheet.ciiva.com:443/pdfs/VipMasterIC/IC/YAGO/YAGO-S-A0008145938/YAGO-S-A0008145938-1.pdf?src-supplier=IHS+Markit; ComponentLink1URL: https://datasheet.ciiva.com:443/pdfs/VipMasterIC/IC/YAGO/YAGO-S-A0008145938/YAGO-S-A0008145938-1.pdf?src-supplier=IHS+Markit; ComponentLink2Description: http://www.yageo.com.tw/exep/pages/download/literatures/PYu-R_INT-thick_7.pdf?src-supplier=Digikey; ComponentLink2URL: http://www.yageo.com.tw/exep/pages/download/literatures/PYu-R_INT-thick_7.pdf?src-supplier=Digikey; Construction: Chip; JESD-609 Code: e3; Library Name: Schlib2.SchLib; Manufacturer Part Number: RC0805DR-072K2L; Max Operating Temperature: 155°C; Min Operating Temperature: -55°C; Mounting Technology: Surface Mount; Package Shape: Rectangular; Package Style: SMTMeter; Packaging: Tape and Reel; Pins: 2; Pricing: 1=0.13, 10=0.063, 50=0.0414, 100=0.0352, 500=0.02476, 1000=0.02156 (USD); Reach SVHC Compliant: Yes; Resistor Type: FIXED RESISTOR; RoHS: Yes; RoHS Compliant: Yes; Stock: 19275; Supplier: Digikey; Supplier Part Number: 13-RC0805DR-072K2LCT-ND; Technology: METAL GLAZE/THICK FILM; Terminal Finish: Nickel; Termination: Wraparound; Tolerance: 0.5%; Working Voltage: 150V
R15 = RT1206FRE07210RL: Fixed Resistor, Thin Film, 0.25W, 210ohm, 200V, 1% +/-Tol, 50ppm/Cel, Surface Mount, 1206; Manufacturer: Yageo; Additional Feature: HIGH PRECISION; Package Height: 0.55mm; Package Length: 3.1mm; Package Width: 1.6mm; Power: 250mW; Rated Temperature: 70°C; Temperature Coefficient (ppm/Cel): 50; Case/Package: 1206; Category: Chip SMD Resistors; Component Kind: Standard; ComponentLink1Description: https://datasheet.ciiva.com:443/pdfs/VipMasterIC/IC/YAGO/YAGO-S-A0008510989/YAGO-S-A0008510989-1.pdf?src-supplier=IHS+Markit; ComponentLink1URL: https://datasheet.ciiva.com:443/pdfs/VipMasterIC/IC/YAGO/YAGO-S-A0008510989/YAGO-S-A0008510989-1.pdf?src-supplier=IHS+Markit; ComponentLink2Description: https://datasheet.ciiva.com/12812/pyu-rt-1-to-0-05-rohs-l-4-12812710.pdf?src-supplier=Mouser; ComponentLink2URL: https://datasheet.ciiva.com/12812/pyu-rt-1-to-0-05-rohs-l-4-12812710.pdf?src-supplier=Mouser; Construction: Chip; Library Name: Schlib2.SchLib; Manufacturer Part Number: RT1206FRE07210RL; Max Operating Temperature: 155°C; Min Operating Temperature: -55°C; Mounting Technology: Surface Mount; Packaging: Tape and Reel; Pins: 2; Pricing: 1=0.1, 10=0.046, 50=0.046, 100=0.034, 1000=0.023, 10000=0.02 (USD); Reach SVHC Compliant: Yes; Resistor Type: FIXED RESISTOR; RoHS: Yes; RoHS Compliant: Yes; Stock: 12403; Supplier: Mouser; Supplier Part Number: 603-RT1206FRE07210RL; Technology: THIN FILM; Termination: Wraparound; Tolerance: 1%; Value: 210R; Working Voltage: 200V
R16 = RT0805FRE0710KL: Fixed Resistor, Thin Film, 0.125W, 10000ohm, 150V, 1% +/-Tol, 50ppm/Cel, Surface Mount, 0805; Manufacturer: Yageo; Additional Feature: HIGH PRECISION; Package Height: 0.5mm; Package Length: 2mm; Package Width: 1.25mm; Power: 125mW; Rated Temperature: 70°C; Temperature Coefficient (ppm/Cel): 50; Value: 10kR; Case/Package: 0805; Category: Chip SMD Resistors; Component Kind: Standard; ComponentLink1Description: https://datasheet.ciiva.com:443/pdfs/VipMasterIC/IC/YAGO/YAGO-S-A0008510989/YAGO-S-A0008510989-1.pdf?src-supplier=IHS+Markit; ComponentLink1URL: https://datasheet.ciiva.com:443/pdfs/VipMasterIC/IC/YAGO/YAGO-S-A0008510989/YAGO-S-A0008510989-1.pdf?src-supplier=IHS+Markit; ComponentLink2Description: https://datasheet.ciiva.com/29711/pyu-rt-1-to-0-05-rohs-l-4-29711644.pdf?src-supplier=Digikey; ComponentLink2URL: https://datasheet.ciiva.com/29711/pyu-rt-1-to-0-05-rohs-l-4-29711644.pdf?src-supplier=Digikey; ComponentLink3Description: https://datasheet.ciiva.com/29711/pyu-rt-1-to-0-05-rohs-l-4-29711645.pdf?src-supplier=Digikey; ComponentLink3URL: https://datasheet.ciiva.com/29711/pyu-rt-1-to-0-05-rohs-l-4-29711645.pdf?src-supplier=Digikey; ComponentLink4Description: https://datasheet.ciiva.com/25647/pyu-rt-1-to-0-05-rohs-l-4-25647309.pdf?src-supplier=Digikey; ComponentLink4URL: https://datasheet.ciiva.com/25647/pyu-rt-1-to-0-05-rohs-l-4-25647309.pdf?src-supplier=Digikey; ComponentLink5Description: https://datasheet.ciiva.com/12834/pyu-rt-1-to-0-05-rohs-l-4-12834887.pdf?src-supplier=Mouser; ComponentLink5URL: https://datasheet.ciiva.com/12834/pyu-rt-1-to-0-05-rohs-l-4-12834887.pdf?src-supplier=Mouser; ComponentLink6Description: https://datasheet.ciiva.com/7942/1392914-7942071.pdf?src-supplier=Element14; ComponentLink6URL: https://datasheet.ciiva.com/7942/1392914-7942071.pdf?src-supplier=Element14; ComponentLink7Description: https://datasheet.ciiva.com/7942/1392914-7942072.pdf?src-supplier=Element14; ComponentLink7URL: https://datasheet.ciiva.com/7942/1392914-7942072.pdf?src-supplier=Element14; Construction: Chip; Library Name: Schlib2.SchLib; Manufacturer Part Number: RT0805FRE0710KL; Max Operating Temperature: 155°C; Min Operating Temperature: -55°C; Mounting Technology: Surface Mount; Packaging: Tape and Reel; Pins: 2; Pricing: 1=0.1, 10=0.024, 50=0.024, 100=0.02, 1000=0.019, 10000=0.008 (USD); Reach SVHC Compliant: Yes; Resistor Type: FIXED RESISTOR; RoHS: Yes; RoHS Compliant: Yes; Stock: 2710; Supplier: Mouser; Supplier Part Number: 603-RT0805FRE0710KL; Technology: THIN FILM; Termination: Wraparound; Tolerance: 1%; Working Voltage: 150V
R17 = RT0805BRD0713K7L: Fixed Resistor, Thin Film, 0.125W, 13700ohm, 150V, 0.1% +/-Tol, 25ppm/Cel, Surface Mount, 0805; Manufacturer: Yageo; Additional Feature: HIGH PRECISION; Package Height: 0.5mm; Package Length: 2mm; Package Width: 1.25mm; Power: 125mW; Rated Temperature: 70°C; Temperature Coefficient (ppm/Cel): 25; Value: 13.7kR; Case/Package: 0805; Category: Chip SMD Resistors; Component Kind: Standard; ComponentLink1Description: https://datasheet.ciiva.com:443/pdfs/VipMasterIC/IC/YAGO/YAGO-S-A0008510989/YAGO-S-A0008510989-1.pdf?src-supplier=IHS+Markit; ComponentLink1URL: https://datasheet.ciiva.com:443/pdfs/VipMasterIC/IC/YAGO/YAGO-S-A0008510989/YAGO-S-A0008510989-1.pdf?src-supplier=IHS+Markit; ComponentLink2Description: https://datasheet.ciiva.com/8890/pyu-rt-1-to-0-05-rohs-l-4-8890950.pdf?src-supplier=Avnet; ComponentLink2URL: https://datasheet.ciiva.com/8890/pyu-rt-1-to-0-05-rohs-l-4-8890950.pdf?src-supplier=Avnet; Construction: Chip; Library Name: Schlib2.SchLib; Manufacturer Part Number: RT0805BRD0713K7L; Max Operating Temperature: 155°C; Min Operating Temperature: -55°C; Mounting Technology: Surface Mount; Packaging: Tape and Reel; Pins: 2; Pricing: 1=0.16, 10=0.12, 50=0.095, 100=0.085, 1000=0.057, 10000=0.038 (USD); Reach SVHC Compliant: Yes; Resistor Type: FIXED RESISTOR; RoHS: Yes; RoHS Compliant: Yes; Stock: 7040; Supplier: Mouser; Supplier Part Number: 603-RT0805BRD0713K7L; Technology: THIN FILM; Termination: Wraparound; Tolerance: 0.1%; Working Voltage: 150V
R20 = RT0805BRD07294RL: Fixed Resistor, Thin Film, 0.125W, 294ohm, 150V, 0.1% +/-Tol, 25ppm/Cel, Surface Mount, 0805; Manufacturer: Yageo; Additional Feature: HIGH PRECISION; Package Height: 0.5mm; Package Length: 2mm; Package Width: 1.25mm; Power: 125mW; Rated Temperature: 70°C; Temperature Coefficient (ppm/Cel): 25; Case/Package: 0805; Category: Chip SMD Resistors; Component Kind: Standard; ComponentLink1Description: https://datasheet.ciiva.com:443/pdfs/VipMasterIC/IC/YAGO/YAGO-S-A0008510989/YAGO-S-A0008510989-1.pdf?src-supplier=IHS+Markit; ComponentLink1URL: https://datasheet.ciiva.com:443/pdfs/VipMasterIC/IC/YAGO/YAGO-S-A0008510989/YAGO-S-A0008510989-1.pdf?src-supplier=IHS+Markit; ComponentLink2Description: https://datasheet.ciiva.com/2584/pyu-rt-1-to-0-05-rohs-l-4-2584907.pdf?src-supplier=Digikey; ComponentLink2URL: https://datasheet.ciiva.com/2584/pyu-rt-1-to-0-05-rohs-l-4-2584907.pdf?src-supplier=Digikey; Construction: Chip; Library Name: Schlib2.SchLib; Manufacturer Part Number: RT0805BRD07294RL; Max Operating Temperature: 155°C; Min Operating Temperature: -55°C; Mounting Technology: Surface Mount; Packaging: Tape and Reel; Pins: 2; Pricing: 1=0.11, 10=0.079, 50=0.063, 100=0.056, 1000=0.043, 10000=0.038 (USD); Reach SVHC Compliant: Yes; Resistor Type: FIXED RESISTOR; RoHS: Yes; RoHS Compliant: Yes; Stock: 3002; Supplier: Mouser; Supplier Part Number: 603-RT0805BRD07294RL; Technology: THIN FILM; Termination: Wraparound; Tolerance: 0.1%; Value: 294R; Working Voltage: 150V
